# Altium schematic sheet 主控转接板-V1.0.SchDoc(主控转接板-V1.0)
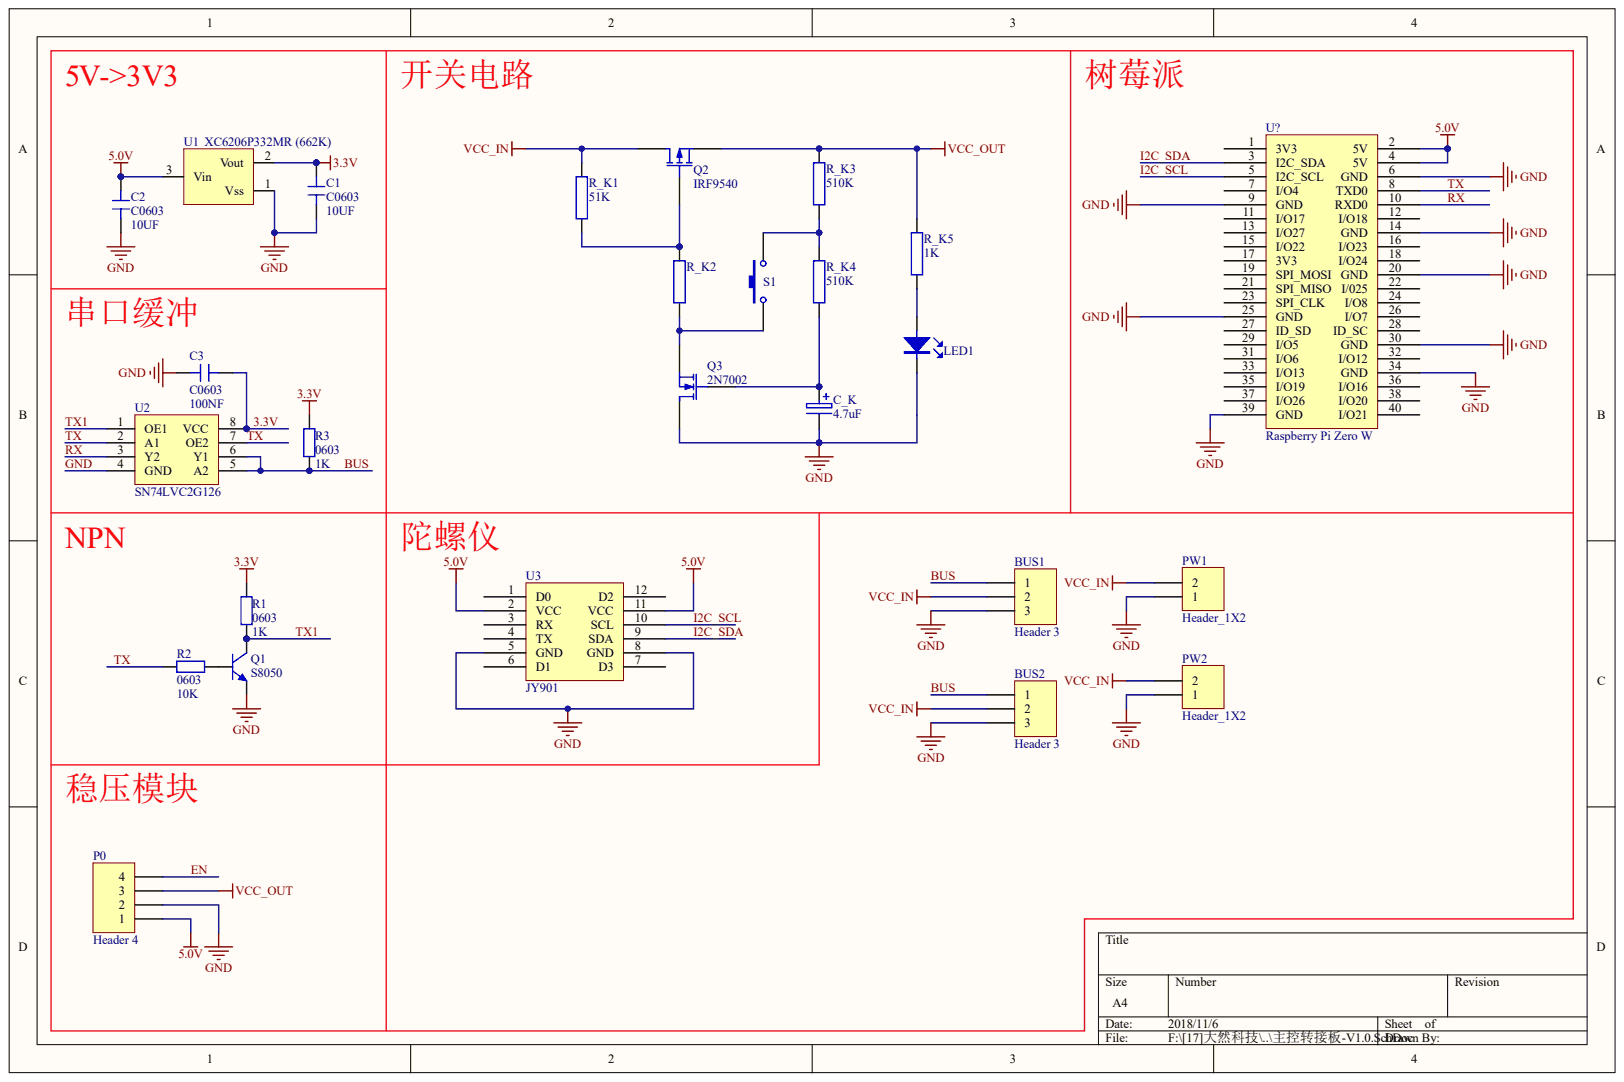
[diagram p0: 主控转接板-V1.0.SchDoc]

## Parts
BUS1  Header 3
BUS2  Header 3
C1  value 10UF  (hidden comment: C0603)
C2  value 10UF  (hidden comment: C0603)
C3  value 100NF  (hidden comment: C0603)
C_K  4.7uF
LED1  LED
P0  Header 4
PW1  Header_1X2
PW2  Header_1X2
Q1  S8050
Q2  IRF9540
Q3  2N7002
R1  value 1K  (hidden comment: 0603)
R2  value 10K  (hidden comment: 0603)
R3  value 1K  (hidden comment: 0603)
R_K1  51K
R_K2  (no value)
R_K3  510K
R_K4  510K
R_K5  1K
S1  SW-PB  (value not drawn)
U1  XC6206P332MR (662K)
U2  SN74LVC2G126
U3  JY901
U?  Raspberry Pi Zero W

## Nets
5.0V: C2.2 P0.1 U1.3 U3.2(VCC) U3.11(VCC) U?.2(5V) U?.4(5V)
VCC_IN: BUS1.2 BUS2.2 PW1.2 PW2.2 Q2.1 R_K1.1
VCC_OUT: P0.3 Q2.3 R_K3.1 R_K5.1
3.3V: C1.2 C3.1 R1.2 R3.2 U1.2 U2.8(VCC)
BUS: BUS1.1 BUS2.1 R3.1 U2.5(A2) U2.6(Y1)
EN: P0.4
GND: BUS1.3 BUS2.3 C1.1 C2.1 C3.2 C_K.2 LED1.K P0.2 PW1.1 PW2.1 Q1.2 Q3.1 U1.1 U2.4(GND) U3.5(GND) U3.8(GND) U?.6(GND) U?.9(GND) U?.14(GND) U?.20(GND) U?.25(GND) U?.30(GND) U?.34(GND) U?.39(GND)
I2C_SCL: U3.10(SCL) U?.5(I2C_SCL)
I2C_SDA: U3.9(SDA) U?.3(I2C_SDA)
RX: U2.3(Y2) U?.10(RXD0)
TX: R2.2 U2.2(A1) U2.7(OE2) U?.8(TXD0)
TX1: Q1.3 R1.1 U2.1(OE1)
NetC_K_1: C_K.1 Q3.2 R_K4.2
NetLED1_A: LED1.A R_K5.2
NetQ1_1: Q1.1 R2.1
NetQ2_2: Q2.2 R_K1.2 R_K2.1
NetQ3_3: Q3.3 R_K2.2 S1.1
NetR_K3_2: R_K3.2 R_K4.1 S1.2

Not connected: U3 1(D0) 3(RX) 4(TX) 6(D1) 7(D3) 12(D2); U? 1(3V3) 7(I/O4) 11(I/O17) 12(I/O18) 13(I/O27) 15(I/O22) 16(I/O23) 17(3V3) 18(I/O24) 19(SPI_MOSI) 21(SPI_MISO) 22(I/025) 23(SPI_CLK) 24(I/O8) 26(I/O7) 27(ID_SD) 28(ID_SC) 29(I/O5) 31(I/O6) 32(I/O12) 33(I/O13) 35(I/O19) 36(I/O16) 37(I/O26) 38(I/O20) 40(I/O21)

## Library data of the parts
C1 C2 = (no symbol name): Pressure resistance: 16V; Accuracy: 5%; Packaging: C0603
C3 = (no symbol name): Pressure resistance: 16V; Accuracy: 5%; value: 100NF; Packaging: C0603
Q2 Q3 = (no symbol name): PackageDocument: 1995/1996; Published: 8-Jun-2000; LatestRevisionDate: 17-Jul-2002; LatestRevisionNote: Re-released for DXP Platform.; PackageReference: E3; Publisher: Altium Limited
R1-R3 = (no symbol name): Accuracy: 1%; Packaging: 0603
BUS1 BUS2 C_K LED1 P0 PW1 PW2 Q1 R_K1-R_K5 S1 U1-U3 U? = (no symbol name)
  BUS1 BUS2 P0: LatestRevisionDate: 17-Jul-2002; LatestRevisionNote: Re-released for DXP Platform.
  Q1: Published: 8-Jun-2000; LatestRevisionDate: 17-Jul-2002; LatestRevisionNote: Re-released for DXP Platform.; PackageReference: TO-226-AA; PackageDescription: TO, Flat Index; 3 In-Line, Axial Leads; Body Dia. 4.8mm; Leads 0.55 x 0.51 mm (max); Code_JEDEC: TO-226-AA; Packaging: *; value: *
  S1: Published: 24-Mar-1999; LatestRevisionDate: 17-Jul-2002; LatestRevisionNote: Re-released for DXP Platform.; PackageReference: SPST-2
  U1 U2: Packaging: *
  BUS1 BUS2 P0 Q1 S1: Publisher: Altium Limited
